# Altium schematic sheet PowerSupply.SchDoc
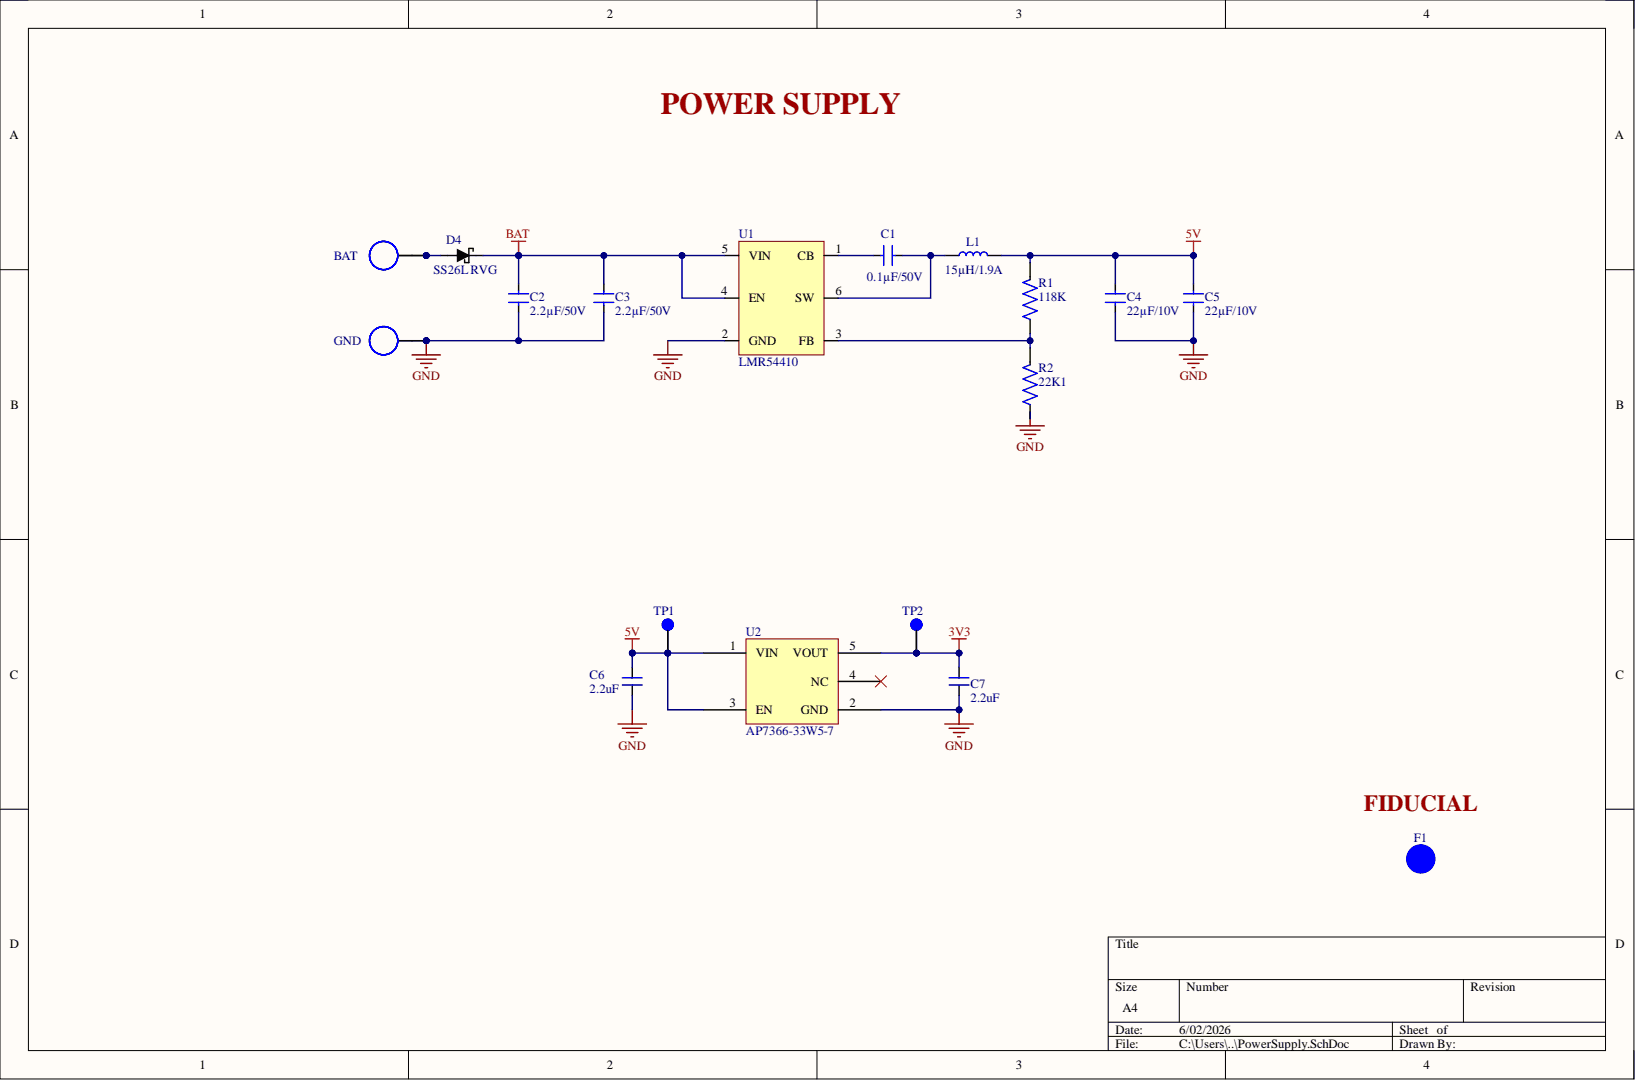
[diagram p0: PowerSupply.SchDoc]

## Parts
BAT  Terminal  [2.5mmHOLE]  (value not drawn)  (no BOM)
C1  0.1µF/50V  [C0402]
C2  2.2µF/50V  [C0603]
C3  2.2µF/50V  [C0603]
C4  22µF/10V  [C0603]
C5  22µF/10V  [C0603]
C6  value 2.2uF  [C0603]  (hidden comment: C0603C225K4PACTU)
C7  value 2.2uF  [C0603]  (hidden comment: C0603C225K4PACTU)
D4  SS26L RVG  [SS26LRVG]
F1  FIDUCIAL  [FID_40x120]  (no BOM)
GND  Terminal  [2.5mmHOLE]  (value not drawn)  (no BOM)
L1  15µH/1.9A  [SRN6045-150M]
R1  value 118K  [R0402]  (hidden comment: RC0402FR-07118KL)
R2  value 22K1  [R0402]  (hidden comment: RC0402FR-0722K1L)
TP1  TP  [TP]  (no BOM)
TP2  TP  [TP]  (no BOM)
U1  LMR54410  [LMR54410]
U2  AP7366-33W5-7  [SOT25]

## Nets
3V3: C7.2 TP2.1 U2.5(VOUT)
5V: C4.2 C5.2 C6.2 L1.2 R1.2 TP1.1 U2.1(VIN) U2.3(EN)
BAT: C2.2 C3.2 D4.1 U1.4(EN) U1.5(VIN)
GND: C2.1 C3.1 C4.1 C5.1 C6.1 C7.1 GND.1 R2.1 U1.2(GND) U2.2(GND)
NetBAT_1: BAT.1 D4.2
NetC1_1: C1.1 U1.1(CB)
NetC1_2: C1.2 L1.1 U1.6(SW)
NetR1_1: R1.1 R2.2 U1.3(FB)

Not connected: F1 1; U2 4(NC)

## Library data of the parts
C1 = GRM155R71H104KE14J: MLCC - 0.1µF 50V  0402 X7R ±10%; Manufacturer: Murata; .PCBChecked: Alex Batista; Capacitance: 100nF; Case Code (Imperial): 0402; Case Code (Metric): 1005; Case/Package: 0402; Component Kind: Standard; ComponentLink1Description: http://search.murata.co.jp/Ceramy/image/img/A01X/G101/ENG/GRM155R71H104KE14-01.pdf?src-supplier=Mouser; ComponentLink1URL: http://search.murata.co.jp/Ceramy/image/img/A01X/G101/ENG/GRM155R71H104KE14-01.pdf?src-supplier=Mouser; ComponentLink2Description: http://search.murata.co.jp/Ceramy/image/img/A01X/G101/ENG/GRM155R71H104KE14-01.pdf?src-supplier=Avnet; ComponentLink2URL: http://search.murata.co.jp/Ceramy/image/img/A01X/G101/ENG/GRM155R71H104KE14-01.pdf?src-supplier=Avnet; ComponentLink3Description: http://search.murata.co.jp/Ceramy/image/img/A01X/G101/ENG/GRM155R71H104KE14-01.pdf?src-supplier=Digi-Key; ComponentLink3URL: http://search.murata.co.jp/Ceramy/image/img/A01X/G101/ENG/GRM155R71H104KE14-01.pdf?src-supplier=Digi-Key; ComponentLink4Description: http://search.murata.co.jp/Ceramy/image/img/A01X/G101/ENG/GRM155R71H104KE14-01.pdf?src-supplier=Avnet+Europe; ComponentLink4URL: http://search.murata.co.jp/Ceramy/image/img/A01X/G101/ENG/GRM155R71H104KE14-01.pdf?src-supplier=Avnet+Europe; Dielectric: X7R; Height: 0.5mm; Lead Free: Lead Free; Length: 0.039inch; Library Name: Schlib1.SchLib; Manufacturer 1: Murata; Manufacturer Part Number: GRM155R71H104KE14J; Manufacturer Part Number 1: GRM155R71H104KE14J; Max Operating Temperature: 125°C; Min Operating Temperature: -55°C; Mount: Surface Mount; Package Quantity: 50000; Packaging: Tape and Reel; Pricing 1: 1=0.10000, 10=0.03300, 50=0.01780, 100=0.01460, 500=0.01040, 1000=0.00817, 2500=0.00742, 5000=0.00683 (USD); Resistor Type: Ceramic; RoHS: Yes; Stock 1: 715500; Supplier 1: Digi-Key; Supplier Part Number 1: 490-13342-1-ND; Termination: SMD/SMT; Thickness: 0.022inch; Tolerance: 10%; Voltage Rating: 50V; Voltage Rating (DC): 50V; Weight: 0.000212oz; Width: 0.5mm; .SCHChecked: Alex Batista
C2 C3 = GRT188R61H225KE13D: MLCC - 2.2µF 50V  0603 X5R ±10%; Manufacturer: Murata; .Mounting: SMT; .PCBChecked: Alex Batista; Dielectric: 1537DielectricType; Material: 4241ConductorMaterial; Value: 2.2uF; Case Code (Imperial): 0603; Case Code (Metric): 1608; Case/Package: 0603; Category: Ceramic Capacitors; Component Kind: Standard; ComponentLink1Description: http://www.farnell.com/datasheets/2165960.pdf?src-supplier=Farnell; ComponentLink1URL: http://www.farnell.com/datasheets/2165960.pdf?src-supplier=Farnell; ComponentLink2Description: https://datasheet.octopart.com/GRT188R61H225KE13D-Murata-datasheet-149523597.pdf?src-supplier=Farnell; ComponentLink2URL: https://datasheet.octopart.com/GRT188R61H225KE13D-Murata-datasheet-149523597.pdf?src-supplier=Farnell; ComponentLink3Description: https://datasheet.octopart.com/GRT188R61H225KE13D-Murata-datasheet-67697752.pdf?src-supplier=Element14; ComponentLink3URL: https://datasheet.octopart.com/GRT188R61H225KE13D-Murata-datasheet-67697752.pdf?src-supplier=Element14; ComponentLink4Description: https://search.murata.co.jp/Ceramy/image/img/A01X/G101/ENG/GRT188R61H225KE13-01.pdf?src-supplier=Digikey; ComponentLink4URL: https://search.murata.co.jp/Ceramy/image/img/A01X/G101/ENG/GRT188R61H225KE13-01.pdf?src-supplier=Digikey; ComponentLink5Description: http://search.murata.co.jp/Ceramy/image/img/A01X/G101/ENG/GRT188R61H225KE13-01.pdf?src-supplier=Digikey; ComponentLink5URL: http://search.murata.co.jp/Ceramy/image/img/A01X/G101/ENG/GRT188R61H225KE13-01.pdf?src-supplier=Digikey; ComponentLink6Description: https://datasheet.octopart.com/GRT188R61H225KE13D-Murata-datasheet-165579666.pdf?src-supplier=TME; ComponentLink6URL: https://datasheet.octopart.com/GRT188R61H225KE13D-Murata-datasheet-165579666.pdf?src-supplier=TME; Height: 1mm; Length: 0.063inch; Library Name: Schlib1.SchLib; Manufacturer 1: Murata; Manufacturer Part Number: GRT188R61H225KE13D; Manufacturer Part Number 1: GRT188R61H225KE13D; Max Operating Temperature: 85°C; Min Operating Temperature: -55°C; Mounting Technology: Surface Mount; Packaging: Cut Tape; Pricing: 1=0.19, 10=0.125, 50=0.0788, 100=0.063, 500=0.04552, 1000=0.04027 (USD); Ratings: AEC-Q200; RoHS: Yes; RoHS Compliant: Yes; Series: GRT; Stock: 50026; Supplier: Digikey; Supplier Part Number: 490-12332-1-ND; Thickness: 0.035inch; Tolerance: 10%; Voltage Rating: 50V; Voltage Rating (DC): 50V; Width: 0.031inch; .SCHChecked: Alex Batista
C4 C5 = CL10A226MP8NUNE: MLCC - 22µF 10V 0603 X5R ±20%; Manufacturer: Samsung; .Mounting: SMT; .PCBChecked: Alex Batista; Capacitor Type: Ceramic; Multilayer: Yes; Value: 22uF; Case/Package: 0603; Category: Ceramic Capacitors; Component Kind: Standard; ComponentLink1Description: https://datasheet.ciiva.com:443/pdfs/VipMasterIC/IC/SEMS/SEMS-S-A0008525088/SEMS-S-A0008525102-1.pdf?src-supplier=IHS+Markit; ComponentLink1URL: https://datasheet.ciiva.com:443/pdfs/VipMasterIC/IC/SEMS/SEMS-S-A0008525088/SEMS-S-A0008525102-1.pdf?src-supplier=IHS+Markit; ComponentLink2Description: https://datasheet.ciiva.com/25711/cl10a226mp8nune-25711532.pdf?src-supplier=Digikey; ComponentLink2URL: https://datasheet.ciiva.com/25711/cl10a226mp8nune-25711532.pdf?src-supplier=Digikey; ComponentLink3Description: https://datasheet.ciiva.com/26838/getdatasheetpartid-746477-26838370.pdf?src-supplier=Verical; ComponentLink3URL: https://datasheet.ciiva.com/26838/getdatasheetpartid-746477-26838370.pdf?src-supplier=Verical; Dielectric Material: Ceramic; Height: 1.05mm; JESD-609 Code: e3; Length: 1.6mm; Library Name: Schlib1.SchLib; Manufacturer 1: Samsung; Manufacturer Part Number: CL10A226MP8NUNE; Manufacturer Part Number 1: CL10A226MP8NUNE; Max Operating Temperature: 85°C; Min Operating Temperature: -55°C; Mounting Technology: Surface Mount; Package Shape: Rectangular; Package Style: SMTMeter; Packaging: Tape and Reel; Pins: 2; Pricing 1: 1=0,13, 10=0,074, 100=0,0471, 1000=0,03264 (USD); Rated DC Voltage (URdc): 10V; Reach SVHC Compliant: Yes; RoHS: Yes; RoHS Compliant: Yes; Stock 1: 4041118; Supplier 1: Digikey; Supplier Part Number 1: 1276-1274-1-ND; Temperature Characteristics Code: X5R; Terminal Finish: Nickel; Termination: Wraparound; Tolerance  (fill in as +-): 20%; Tolerance (fill in as +-): 20%; Width: 0.8mm; .SCHChecked: Alex Batista
C6 C7 = C0603C225K4PACTU: Ceramic Capacitor, Multilayer, Ceramic, 16V, 10% +Tol, 10% -Tol, X5R, 15% TC, 2.2uF, Surface Mount, 0603; Manufacturer: KEMET; Dissipation Factor: 5%; Case Code (Imperial): 0603; Case Code (Metric): 1608; Case/Package: 0603; Category: Ceramic Capacitors; Component Kind: Standard; ComponentLink1Description: https://datasheet.ciiva.com/5969/1776083-5969304.pdf?src-supplier=Farnell; ComponentLink1URL: https://datasheet.ciiva.com/5969/1776083-5969304.pdf?src-supplier=Farnell; ComponentLink2Description: https://datasheet.ciiva.com/7823/1776083-7823706.pdf?src-supplier=Element14; ComponentLink2URL: https://datasheet.ciiva.com/7823/1776083-7823706.pdf?src-supplier=Element14; Construction: Flat; Depth: 0.8mm; Dielectric: X5R; Dielectric Material: Ceramic; Height: 0.8mm; Lead Free: 5697LeadFree; Length: 1.6mm; Library Name: Schlib1.SchLib; Manufacturer Part Number: C0603C225K4PACTU; Material: Ceramic; Max Operating Temperature: 85°C; Min Operating Temperature: -55°C; Mounting Technology: Surface Mount; Package Quantity: 1; Packaging: Tape and Reel; Pins: 2; Pricing: 1=0,12, 10=0,059, 50=0,059, 100=0,039, 1000=0,027, 10000=0,017 (USD); Radiation Hardening: No; Reach SVHC Compliant: No; RoHS: Yes; RoHS Compliant: Yes; Series: C; Stock: 124238; Supplier: Mouser; Supplier Part Number: 80-C0603C225K4P; Termination: SMD/SMT; Thickness: 0.034inch; Tolerance: 10%; Voltage: 16V; Voltage Rating: 16V; Voltage Rating (DC): 16V; Width: 0.031inch
D4 = SS26L RVG: DIODE - Schottky 60V 2A 2-Pin Sub SMA T/R; Manufacturer: Taiwan Semiconductor; .Mouting: SMT; .PCBChecked: Alex Batista; Component Kind: Standard; Library Name: Schlib1.SchLib; Manufacturer 1: Taiwan Semiconductor; Manufacturer Part Number: SS26L RVG; Manufacturer Part Number 1: SS26L RVG; Pricing: 1=0.66, 10=0.578 (USD); RoHS: Yes; RoHS Compliant: Yes; Stock: 38; Supplier: Digi-Key; Supplier Part Number: SS26LRVGCT-ND; .SCHChecked: Alex Batista
L1 = SRN6045-150M: IND - 15µH Semi-Shielded 1.9 A 95.8mOhm; Manufacturer: Bourns; .Mouting: SMT; .PCBChecked: Alex Batista; Core Material: Ferrite; Current Rating: 1.9A; DC Resistance (DCR): 95.8mR; Inductance Tolerance: 20%; Saturation Current: 2.3A; Self Resonant Frequency: 13MHz; Test Frequency: 100kHz; Value: 15uH; Case/Package: Nonstandard; Category: Fixed Inductors; Component Kind: Standard; ComponentLink1Description: https://datasheet.ciiva.com/5986/1705518-5986195.pdf?src-supplier=Farnell; ComponentLink1URL: https://datasheet.ciiva.com/5986/1705518-5986195.pdf?src-supplier=Farnell; ComponentLink2Description: https://datasheet.ciiva.com/11802/0900766b80fe1f3e-11802573.pdf?src-supplier=Rs; ComponentLink2URL: https://datasheet.ciiva.com/11802/0900766b80fe1f3e-11802573.pdf?src-supplier=Rs; ComponentLink3Description: https://datasheet.ciiva.com/4954/srn6045-70649-4954231.pdf?src-supplier=Mouser; ComponentLink3URL: https://datasheet.ciiva.com/4954/srn6045-70649-4954231.pdf?src-supplier=Mouser; ComponentLink4Description: https://datasheet.ciiva.com/8512/srn6045-8512989.pdf?src-supplier=Avnet; ComponentLink4URL: https://datasheet.ciiva.com/8512/srn6045-8512989.pdf?src-supplier=Avnet; ComponentLink5Description: https://datasheet.ciiva.com/1056/srn6045-1056106.pdf?src-supplier=Digi-Key; ComponentLink5URL: https://datasheet.ciiva.com/1056/srn6045-1056106.pdf?src-supplier=Digi-Key; ComponentLink6Description: https://datasheet.ciiva.com/1056/srn6045-1056107.pdf?src-supplier=Digi-Key; ComponentLink6URL: https://datasheet.ciiva.com/1056/srn6045-1056107.pdf?src-supplier=Digi-Key; ComponentLink7Description: https://datasheet.ciiva.com/1056/srn6045-1056108.pdf?src-supplier=Digi-Key; ComponentLink7URL: https://datasheet.ciiva.com/1056/srn6045-1056108.pdf?src-supplier=Digi-Key; ComponentLink8Description: https://datasheet.ciiva.com/17113/70231653-17113165.pdf?src-supplier=Allied+Electronics; ComponentLink8URL: https://datasheet.ciiva.com/17113/70231653-17113165.pdf?src-supplier=Allied+Electronics; Depth: 6mm; Height: 4.5mm; Height - Seated (Max): 0.177inch; Length: 6mm; Library Name: Schlib1.SchLib; Manufacturer 1: Bourns; Manufacturer Part Number: SRN6045-150M; Manufacturer Part Number 1: SRN6045-150M; Max DC Current: 1.9A; Max Operating Temperature: 125°C; Min Operating Temperature: -40°C; Mounting Technology: Surface Mount; Package Quantity: 1000; Packaging: Tape and Reel; Pins: 2; Pricing: 1=0.57, 10=0.499, 25=0.464, 50=0.3924, 100=0.3568, 250=0.33536, 500=0.2997 (USD); Reach SVHC Compliant: No; RMS Current (Irms): 1.9A; RoHS: Yes; RoHS Compliant: Yes; Series: SRN6045; Shielding: Shielded; Stock: 65513; Supplier: Digi-Key; Supplier Part Number: SRN6045-150MCT-ND; Termination: SMD/SMT; Tolerance: 20%; Weight: 0.027725oz; Width: 6mm; .SCHChecked: Alex Batista
R1 = RC0402FR-07118KL: RES - 118K 1/16W 0402 ±1%; Manufacturer: Yageo; .Mouting: SMT; .PCBChecked: Alex Batista; Max Power Dissipation: 63mW; Power Rating: 62.5mW; Temperature Coefficient: 100ppm/°C; Voltage Rating: 50V; Case Code (Imperial): 0402; Case Code (Metric): 1005; Case/Package: 0402; Category: Chip SMD Resistors; Component Kind: Standard; ComponentLink1Description: https://datasheet.ciiva.com/8887/pyu-rc0402-51-rohs-l-6-8887658.pdf?src-supplier=Avnet; ComponentLink1URL: https://datasheet.ciiva.com/8887/pyu-rc0402-51-rohs-l-6-8887658.pdf?src-supplier=Avnet; ComponentLink2Description: https://datasheet.ciiva.com/12558/pyu-rc0402-51-rohs-l-6-r-8721-12558524.pdf?src-supplier=Mouser; ComponentLink2URL: https://datasheet.ciiva.com/12558/pyu-rc0402-51-rohs-l-6-r-8721-12558524.pdf?src-supplier=Mouser; ComponentLink3Description: https://datasheet.ciiva.com/2552/pyu-r-int-thick-7-2552967.pdf?src-supplier=Digi-Key; ComponentLink3URL: https://datasheet.ciiva.com/2552/pyu-r-int-thick-7-2552967.pdf?src-supplier=Digi-Key; ComponentLink4Description: https://datasheet.ciiva.com/26829/getdatasheetpartid-702380-26829882.pdf?src-supplier=Verical; ComponentLink4URL: https://datasheet.ciiva.com/26829/getdatasheetpartid-702380-26829882.pdf?src-supplier=Verical; Construction: Rectangular; Contact Plating: Tin,Matte; Depth: 0.5mm; Features: Moisture Resistant; Height: 0.016inch; Lead Free: Lead Free; Length: 1mm; Library Name: Schlib1.SchLib; Manufacturer 1: Yageo; Manufacturer Part Number: RC0402FR-07118KL; Manufacturer Part Number 1: RC0402FR-07118KL; Max Operating Temperature: 155°C; Military Standard: Not; Min Operating Temperature: -55°C; Number of Terminations: 2; Package Quantity: 10000; Packaging: Tape and Reel; Pricing: 1=0.1, 10=0.014, 50=0.0084, 100=0.007, 500=0.00464, 1000=0.00396, 5000=0.00282 (USD); RoHS: Yes; RoHS Compliant: Yes; Series: RC; Stock: 556112; Supplier: Digi-Key; Supplier Part Number: YAG2369CT-ND; Termination: SMD/SMT; Tolerance: 1%; Voltage Rating (DC): 50V; Width: 0.5mm; .SCHChecked: Alex Batista
R2 = RC0402FR-0722K1L: RES - 22K1 1/16W 0402 ±1%; Manufacturer: Yageo; .Mouting: SMT; .PCBChecked: Alex Batista; Max Power Dissipation: 63mW; Power Rating: 62.5mW; Temperature Coefficient: 100ppm/°C; Voltage Rating: 50V; Case Code (Imperial): 0402; Case Code (Metric): 1005; Case/Package: 0402; Category: Chip SMD Resistors; Component Kind: Standard; ComponentLink1Description: https://datasheet.ciiva.com/2553/pyu-r-int-thick-7-2553200.pdf?src-supplier=Digi-Key; ComponentLink1URL: https://datasheet.ciiva.com/2553/pyu-r-int-thick-7-2553200.pdf?src-supplier=Digi-Key; ComponentLink2Description: https://datasheet.ciiva.com/2553/pyu-r-int-thick-7-2553201.pdf?src-supplier=Digi-Key; ComponentLink2URL: https://datasheet.ciiva.com/2553/pyu-r-int-thick-7-2553201.pdf?src-supplier=Digi-Key; ComponentLink3Description: https://datasheet.ciiva.com/2553/pyu-r-int-thick-7-2553202.pdf?src-supplier=Digi-Key; ComponentLink3URL: https://datasheet.ciiva.com/2553/pyu-r-int-thick-7-2553202.pdf?src-supplier=Digi-Key; Construction: Rectangular; Depth: 0.5mm; Features: Moisture Resistant; Height: 0.016inch; Lead Free: Lead Free; Length: 0.039inch; Library Name: Schlib1.SchLib; Manufacturer 1: Yageo; Manufacturer Part Number: RC0402FR-0722K1L; Manufacturer Part Number 1: RC0402FR-0722K1L; Max Operating Temperature: 155°C; Military Standard: Not; Min Operating Temperature: -55°C; Number of Terminations: 2; Package Quantity: 1; Packaging: Tape and Reel; Pricing: 1=0.1, 10=0.014, 50=0.0084, 100=0.007, 500=0.00464, 1000=0.00396, 5000=0.00282 (USD); Reach SVHC Compliant: No; Resistance Tolerance: 1%; Resistor Type: Thick Film; RoHS: Yes; RoHS Compliant: Yes; Series: RC; Stock: 435944; Supplier: Digi-Key; Supplier Part Number: 311-22.1KLRCT-ND; Technology: THICK FILM; Termination: SMD/SMT; Tolerance: 1%; Voltage Rating (DC): 50V; Width: 0.02inch; Working Voltage: 50V; .SCHChecked: Alex Batista
TP1 TP2 = TP: Test Point; Library Name: Schlib1.SchLib
U1 = LMR54410DBVR: IC - DC/DC BUCK, Current-mode, 1A, 1100kHz SOT32-6; Manufacturer: Texas Instruments; .Mouting: SMT; .PCBChecked: Alex Batista; Category: Voltage Regulators - Switching; Component Kind: Standard; Library Name: Schlib1.SchLib; Manufacturer 1: Texas Instruments; Manufacturer Part Number: LMR54410DBVR; Manufacturer Part Number 1: LMR54410DBVR; Pricing: 1=1.07, 10=0.959, 25=0.9108, 100=0.748, 250=0.69924, 500=0.61792, 1000=0.48783 (USD); RoHS: No; Stock: 13950; Supplier: Digi-Key; Supplier Part Number: 296-LMR54410DBVRCT-ND; .SCHChecked: Alex Batista
U2 = AP7366-33W5-7: Ldo, Fixed, 3.3V, 0.6A, -40 To 85Deg C Rohs Compliant: Yes |Diodes Inc. AP7366-33W5-7; Manufacturer: Diodes; Number of Channels: 1NUMBER; Component Kind: Standard; ComponentLink1Description: https://datasheet.octopart.com/AP7366-33W5-7-Diodes-Inc.-datasheet-147761854.pdf?src-supplier=Farnell; ComponentLink1URL: https://datasheet.octopart.com/AP7366-33W5-7-Diodes-Inc.-datasheet-147761854.pdf?src-supplier=Farnell; ComponentLink2Description: https://www.diodes.com/assets/Datasheets/AP7366.pdf?src-supplier=Digikey; ComponentLink2URL: https://www.diodes.com/assets/Datasheets/AP7366.pdf?src-supplier=Digikey; Library Name: Schlib1.SchLib; Manufacturer Part Number: AP7366-33W5-7; Output Voltage: 3.3V; Pricing: 3000=0,0633, 9000=0,0609, 15000=0,0598, 30000=0,0584, 60000=0,0561 (USD); RoHS: Yes; Stock: 9000; Supplier: Future Electronics; Supplier Part Number: 8130059
BAT GND = Terminal: Library Name: Schlib1.SchLib
F1 = FIDUCIAL: Library Name: Schlib1.SchLib
